# Altium schematic sheet RTC.SchDoc(RTC)
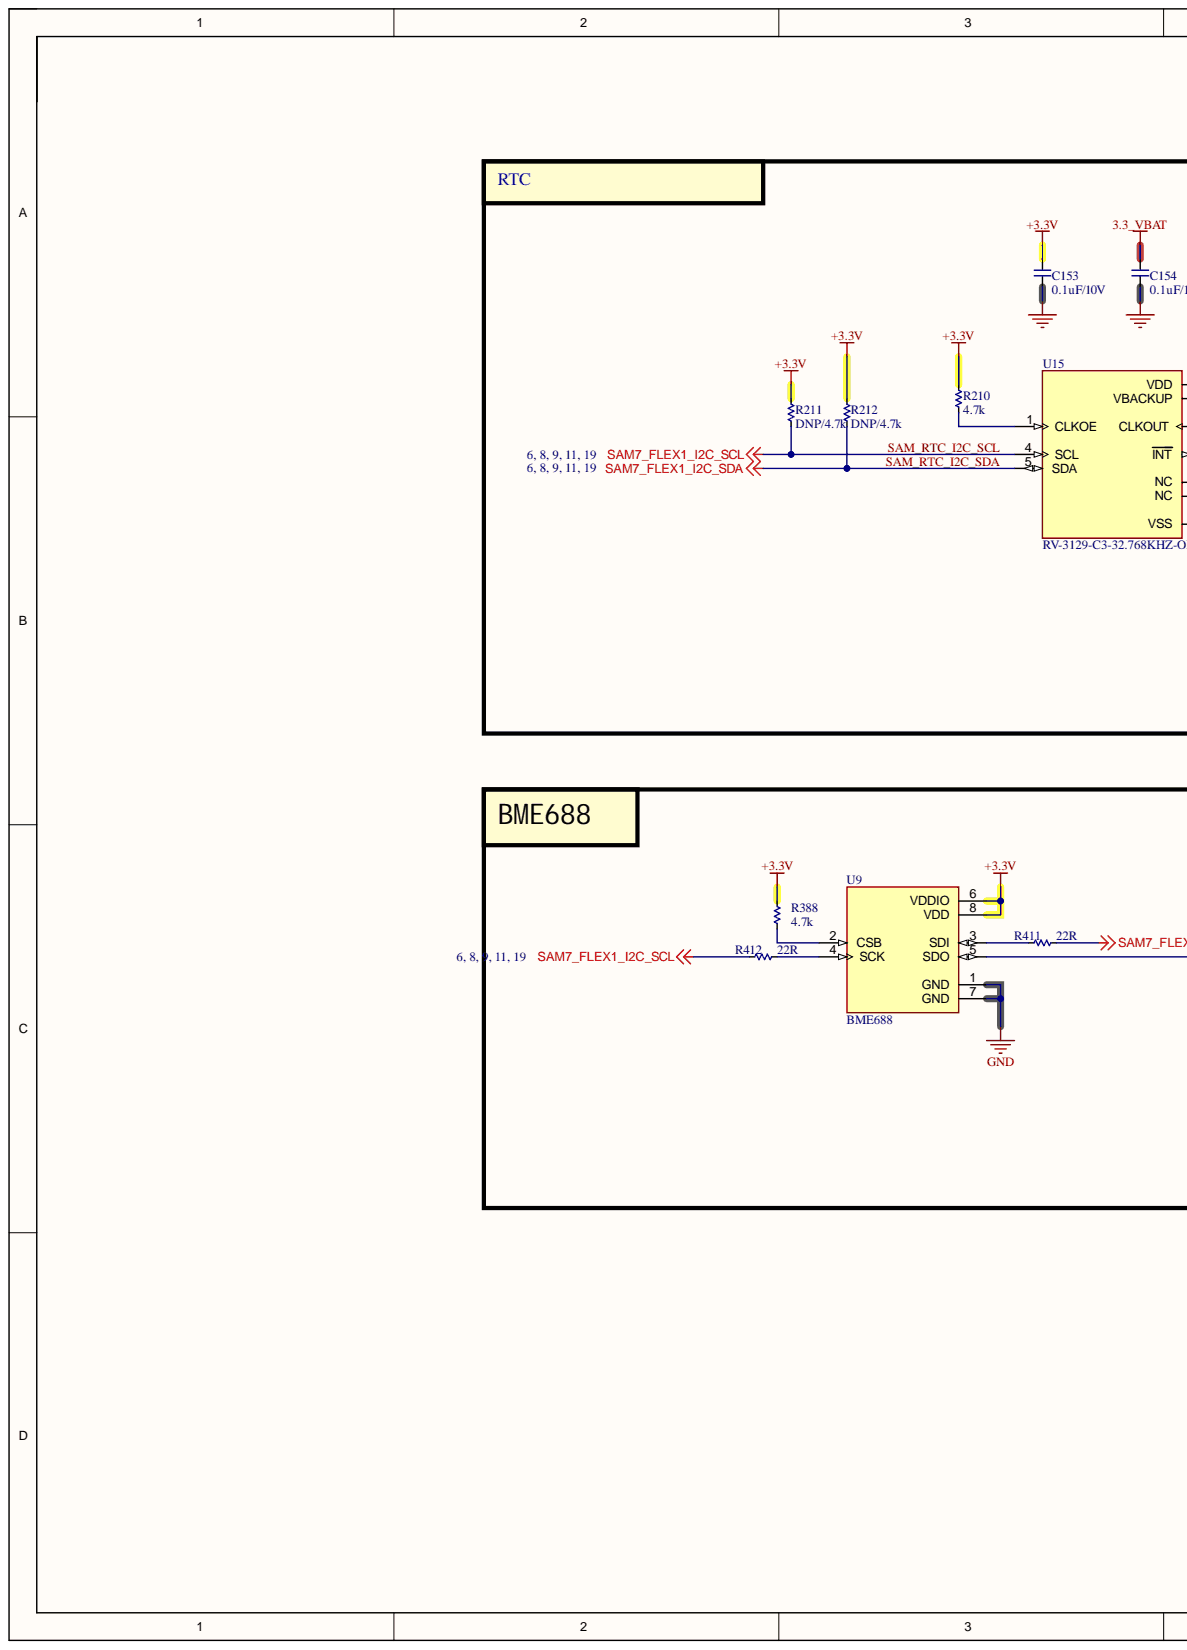
[diagram p0: RTC.SchDoc, left]
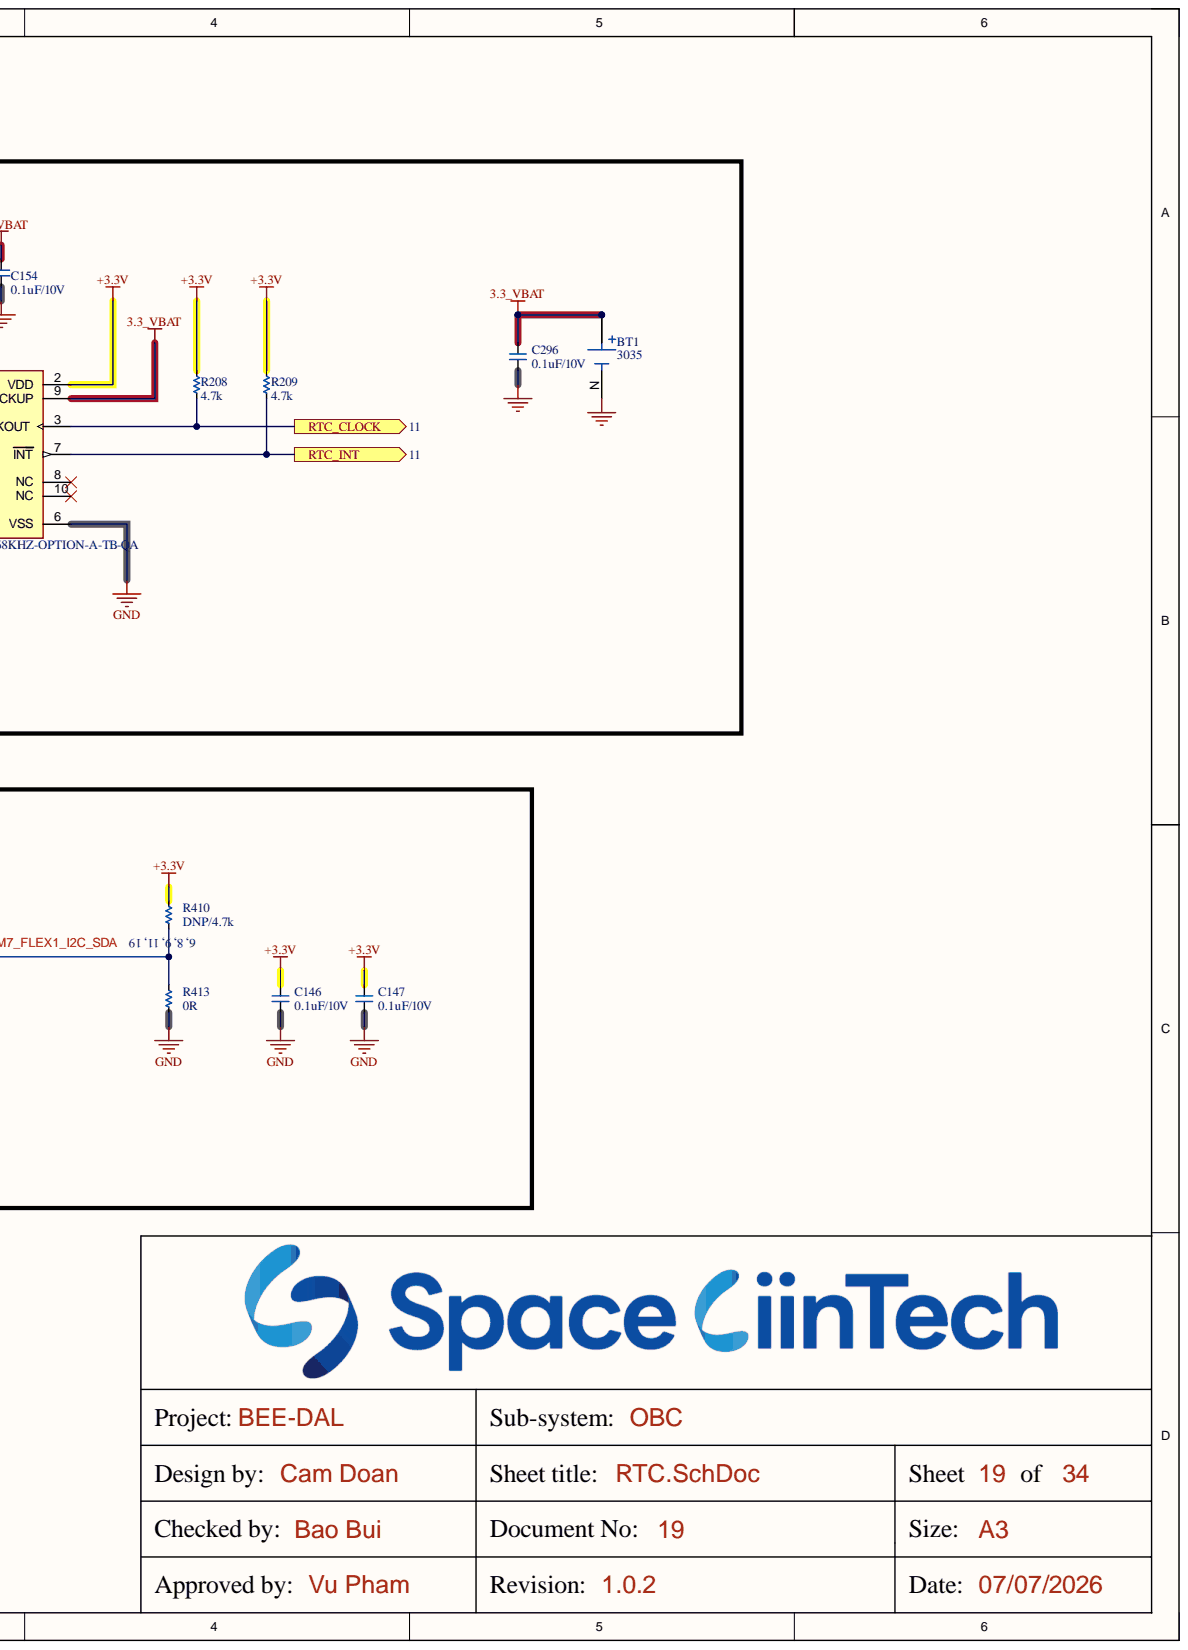
[diagram p1: RTC.SchDoc, right]

## Parts
BT1  3035  [BAT_3035]
C146  0.1uF/10V  [CAP_C_1005X60]
C147  0.1uF/10V  [CAP_C_1005X60]
C153  0.1uF/10V  [CAP_C_1005X50_L]
C154  0.1uF/10V  [CAP_C_1005X50_L]
C296  0.1uF/10V  [CAP_C_1005X60]
R208  4.7k  [RES_C_1005x30_L]
R209  4.7k  [RES_C_1005x30_L]
R210  4.7k  [RES_C_1005x30_L]
R211  DNP/4.7k  [RES_C_1005x30_L]
R212  DNP/4.7k  [RES_C_1005x30_L]
R388  4.7k  [RES_C_1005X40]
R410  DNP/4.7k  [RES_C_1005X40]
R411  22R  [RES_C_1005X40]
R412  22R  [RES_C_1005X40]
R413  0R  [RES_C_1005X40]
U9  BME688  [IC_BME688]
U15  RV-3129-C3-32.768KHZ-OPTION-A-TB-QA  [IC_RV-3129-C3-32.768KHZ-OPTION-A-TB-QA]

## Ports
RTC_CLOCK, RTC_INT

## Nets
3.3_VBAT: BT1.P1 BT1.P2 C146.2 C147.2 C153.2 C154.2 C296.2 R208.1 R209.1 R210.1 R211.1 R212.1 R388.1 R410.1 U9.6(VDDIO) U9.8(VDD) U15.2(VDD) U15.9(VBACKUP)
GND: BT1.N C146.1 C147.1 C153.1 C154.1 C296.1 R413.2 U9.1(GND) U9.7(GND) U15.6(VSS)
RTC_CLOCK: R208.2 U15.3(CLKOUT) ; port RTC_CLOCK
RTC_INT: R209.2 U15.7(INT) ; port RTC_INT
SAM_RTC_I2C_SCL: R211.2 R412.1 U15.4(SCL)
SAM_RTC_I2C_SDA: R212.2 R411.2 U15.5(SDA)
NetR210_2: R210.2 U15.1(CLKOE)
NetR388_2: R388.2 U9.2(CSB)
NetR410_2: R410.2 R413.1 U9.5(SDO)
NetR411_1: R411.1 U9.3(SDI)
NetR412_2: R412.2 U9.4(SCK)

Not connected: U15 8(NC) 10(NC)

## Library data of the parts
BT1 = BT_HOLDER_3035: Battery Clip; THM; 20mm Cell; PhosBronze/Nickel | Keystone Electronics 3035; Check_prices: https://www.snapeda.com/parts/3035/Keystone/view-part/?ref=eda; Component Kind: Standard; MF: Keystone Electronics; MP: 3035; Package: None; SnapEDA_Link: https://www.snapeda.com/parts/3035/Keystone/view-part/?ref=snap; Library Name: LABLIB.SchLib
C146 C147 C153 C154 C296 = _CAP_C_2: CAP; Component Kind: Standard; Library Name: LABLIB.SchLib
R208-R212 R388 R410-R413 = _RES_B_2: RES; Component Kind: Standard
  R208-R212: Library Name: IFBschelib.SchLib
  R388 R410-R413: Library Name: LABLIB.SchLib
U9 = BME688: Gas sensor measuring relative humidity, barometric pressure, ambient temperature and gas (VOC); Availability: Unavailable; Component Kind: Standard; MP: BME688; Package: None; Price: None; Library Name: LABLIB.SchLib
U15 = RV-3129-C3-32.768KHZ-OPTION-A-TB-QA: Real-Time Clock Module with I2C-Bus; Availability: Unavailable; Component Kind: Standard; MP: RV-3129-C3-32.768KHZ-OPTION-A-TB-QA; Package: None; Price: None; Library Name: IFBschelib.SchLib
